# Altium schematic sheet EPM240T100C5N.SchDoc
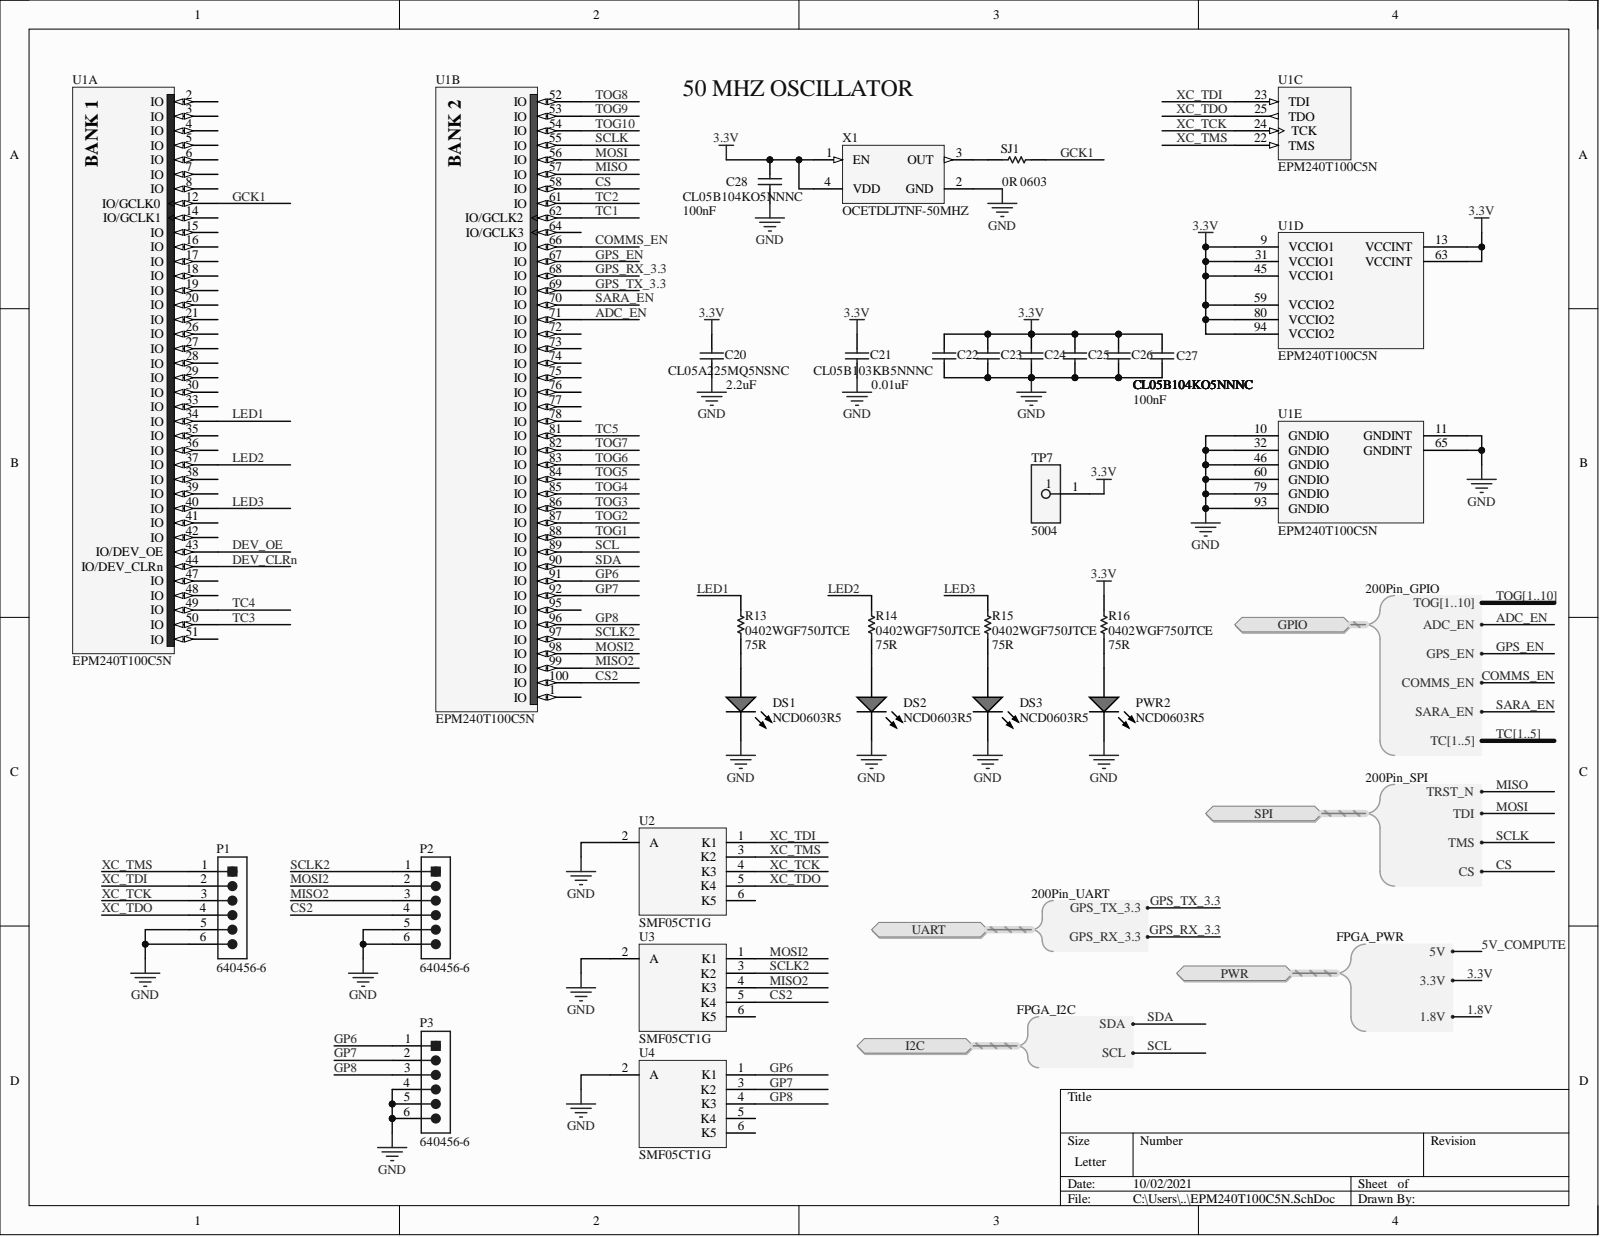
[diagram p0: EPM240T100C5N.SchDoc]

## Parts
C20  CL05A225MQ5NSNC  value 2.2uF  [CAPC0402(1005)60_M]
C21  CL05B103KB5NNNC  [CAPC1005X55X30NL05T10]
C22  CL05B104KO5NNNC  [CAPC1005X55X30ML05T10]
C23  CL05B104KO5NNNC  [CAPC1005X55X30ML05T10]
C24  CL05B104KO5NNNC  [CAPC1005X55X30ML05T10]
C25  CL05B104KO5NNNC  [CAPC1005X55X30ML05T10]
C26  CL05B104KO5NNNC  [CAPC1005X55X30ML05T10]
C27  CL05B104KO5NNNC  [CAPC1005X55X30ML05T10]
C28  CL05B104KO5NNNC  [CAPC1005X55X30ML05T10]
DS1  NCD0603R5  [AVAG-HSMX-C190_V]
DS2  NCD0603R5  [AVAG-HSMX-C190_V]
DS3  NCD0603R5  [AVAG-HSMX-C190_V]
P1  640456-6  [TECO-640456-6_V]
P2  640456-6  [TECO-640456-6_V]
P3  640456-6  [TECO-640456-6_V]
PWR2  NCD0603R5  [AVAG-HSMX-C190_V]
R13  0402WGF750JTCE  spec: 75R  [RESC1005X40X25ML05T10]
R14  0402WGF750JTCE  spec: 75R  [RESC1005X40X25ML05T10]
R15  0402WGF750JTCE  spec: 75R  [RESC1005X40X25ML05T10]
R16  0402WGF750JTCE  spec: 75R  [RESC1005X40X25ML05T10]
SJ1  0R 0603  [RESC1609X55X30ML10T20]
TP7  5004  [FP-5004-MFG]
U1  EPM240T100C5N  [TQFP100_L]  (parts A,B,C,D,E)
U2  SMF05CT1G  [ONSC-SC70-6-419B-02_W_V]
U3  SMF05CT1G  [ONSC-SC70-6-419B-02_W_V]
U4  SMF05CT1G  [ONSC-SC70-6-419B-02_W_V]
X1  OCETDLJTNF-50MHZ  [TXC-L500W700H130-4_V]

## Ports
GPIO, GPIO.ADC_EN, GPIO.COMMS_EN, GPIO.GPS_EN, GPIO.SARA_EN, GPIO.TC1, GPIO.TC2, GPIO.TC3, GPIO.TC4, GPIO.TC5, GPIO.TC[1..5], GPIO.TOG1, GPIO.TOG2, GPIO.TOG3, GPIO.TOG4, GPIO.TOG5, GPIO.TOG6, GPIO.TOG7, GPIO.TOG8, GPIO.TOG9, GPIO.TOG10, GPIO.TOG[1..10], I2C, I2C.SCL, I2C.SDA, PWR, PWR.1.8V, PWR.3.3V, PWR.5V, SPI, SPI.CS, SPI.TDI, SPI.TMS, SPI.TRST_N, UART, UART.GPS_RX_3.3, UART.GPS_TX_3.3

## Nets
GND: C20.1 C21.1 C22.1 C23.1 C24.1 C25.1 C26.1 C27.1 C28.1 DS1.2 DS2.2 DS3.2 P1.5 P1.6 P2.5 P2.6 P3.4 P3.5 P3.6 PWR2.2 U1.10(GNDIO) U1.11(GNDINT) U1.32(GNDIO) U1.46(GNDIO) U1.60(GNDIO) U1.65(GNDINT) U1.79(GNDIO) U1.93(GNDIO) U2.2(A) U3.2(A) U4.2(A) X1.2(GND)
3.3V: C20.2 C21.2 C22.2 C23.2 C24.2 C25.2 C26.2 C27.2 C28.2 R16.2 TP7.1 U1.9(VCCIO1) U1.13(VCCINT) U1.31(VCCIO1) U1.45(VCCIO1) U1.59(VCCIO2) U1.63(VCCINT) U1.80(VCCIO2) U1.94(VCCIO2) X1.1(EN) X1.4(VDD) ; port PWR
ADC_EN: U1.71(IO) ; port GPIO
COMMS_EN: U1.66(IO) ; port GPIO
CS: U1.58(IO) ; port SPI
CS2: P2.4 U1.100(IO) U3.5(K4)
DEV_CLRn: U1.44(IO/DEV_CLRn)
DEV_OE: U1.43(IO/DEV_OE)
GCK1: SJ1.2 U1.12(IO/GCLK0)
GP6: P3.1 U1.91(IO) U4.1(K1)
GP7: P3.2 U1.92(IO) U4.3(K2)
GP8: P3.3 U1.96(IO) U4.4(K3)
GPS_EN: U1.67(IO) ; port GPIO
GPS_RX_3.3: U1.68(IO) ; port UART
GPS_TX_3.3: U1.69(IO) ; port UART
LED1: R13.2 U1.34(IO)
LED2: R14.2 U1.37(IO)
LED3: R15.2 U1.40(IO)
MISO: U1.57(IO) ; port SPI
MISO2: P2.3 U1.99(IO) U3.4(K3)
MOSI: U1.56(IO) ; port SPI
MOSI2: P2.2 U1.98(IO) U3.1(K1)
SARA_EN: U1.70(IO) ; port GPIO
SCL: U1.89(IO) ; port I2C
SCLK: U1.55(IO) ; port SPI
SCLK2: P2.1 U1.97(IO) U3.3(K2)
SDA: U1.90(IO) ; port I2C
TC1: U1.62(IO/GCLK2) ; port GPIO  [also labelled TC]
TC2: U1.61(IO) ; port GPIO  [also labelled TC]
TC3: U1.50(IO) ; port GPIO  [also labelled TC]
TC4: U1.49(IO) ; port GPIO  [also labelled TC]
TC5: U1.81(IO) ; port GPIO  [also labelled TC]
TOG1: U1.88(IO) ; port GPIO  [also labelled TOG]
TOG2: U1.87(IO) ; port GPIO  [also labelled TOG]
TOG3: U1.86(IO) ; port GPIO  [also labelled TOG]
TOG4: U1.85(IO) ; port GPIO  [also labelled TOG]
TOG5: U1.84(IO) ; port GPIO  [also labelled TOG]
TOG6: U1.83(IO) ; port GPIO  [also labelled TOG]
TOG7: U1.82(IO) ; port GPIO  [also labelled TOG]
TOG8: U1.52(IO) ; port GPIO  [also labelled TOG]
TOG9: U1.53(IO) ; port GPIO  [also labelled TOG]
TOG10: U1.54(IO) ; port GPIO  [also labelled TOG]
XC_TCK: P1.3 U1.24(TCK) U2.4(K3)
XC_TDI: P1.2 U1.23(TDI) U2.1(K1)
XC_TDO: P1.4 U1.25(TDO) U2.5(K4)
XC_TMS: P1.1 U1.22(TMS) U2.3(K2)
NetDS1_1: DS1.1 R13.1
NetDS2_1: DS2.1 R14.1
NetDS3_1: DS3.1 R15.1
NetPWR2_1: PWR2.1 R16.1
NetSJ1_1: SJ1.1 X1.3(OUT)
NetU1_1: U1.1(IO)
NetU1_2: U1.2(IO)
NetU1_3: U1.3(IO)
NetU1_4: U1.4(IO)
NetU1_5: U1.5(IO)
NetU1_6: U1.6(IO)
NetU1_7: U1.7(IO)
NetU1_8: U1.8(IO)
NetU1_14: U1.14(IO/GCLK1)
NetU1_15: U1.15(IO)
NetU1_18: U1.18(IO)
NetU1_19: U1.19(IO)
NetU1_20: U1.20(IO)
NetU1_21: U1.21(IO)
NetU1_26: U1.26(IO)
NetU1_27: U1.27(IO)
NetU1_33: U1.33(IO)
NetU1_35: U1.35(IO)
NetU1_36: U1.36(IO)
NetU1_39: U1.39(IO)
NetU1_41: U1.41(IO)
NetU1_42: U1.42(IO)
NetU1_64: U1.64(IO/GCLK3)
NetU1_74: U1.74(IO)
NetU1_77: U1.77(IO)
NetU1_78: U1.78(IO)
NetU1_95: U1.95(IO)
NetU2_6: U2.6(K5)
NetU3_6: U3.6(K5)
NetU4_5: U4.5(K4)
NetU4_6: U4.6(K5)
1.8V: ; port PWR
5V_COMPUTE: ; port PWR

Not connected: U1 16(IO) 17(IO) 28(IO) 29(IO) 30(IO) 38(IO) 47(IO) 48(IO) 51(IO) 72(IO) 73(IO) 75(IO) 76(IO)

## Library data of the parts
C20 = CMP-1034-02125-1: 2.2uF 0402; Case-EIA: 0402; Case-Metric: 1005; Rated Voltage: 6.3 V; Tolerance: Â±10%; Component Kind: Standard; Library Name: Altium Content Vault; Max Thickness: 0.6 mm; Technology: SMT
C21 = CMP-2008-03072-1: 0.01uF 0402; Manufacturer: Kemet; Part Number: C0402C103K4RACTU; Component Kind: Standard; Library Name: Altium Content Vault
C22-C28 = CMP-2008-03080-1: 100nF 0402; Manufacturer: Kemet; Part Number: C0402C104J4RACTU; Component Kind: Standard; Library Name: Altium Content Vault
DS1-DS3 PWR2 = CMP-1744-00002-1: Chip LED, Green, 2.2 V, -40 to 85 degC, 2-Pin SMD, RoHS, Tape and Reel; Access Time: 3500 ns; Case\Package: SOIC; Clock Frequency: 100 KHz; Density: 32 kb; Frequency: 400 KHz; Interface: Serial; Max Supply Voltage: 5.5 V; Min Supply Voltage: 1.7 V; Nominal Supply Current: 2 mA; Component Kind: Standard; ComponentLink1Description: Manufacturer URL; ComponentLink1URL: http://www.avagotech.com/; ComponentLink2Description: Datasheet; ComponentLink2URL: http://docs.avagotech.com/docs/AV02-0551EN; ComponentLink3Description: Package Specification; ComponentLink3URL: http://www.fairchildsemi.com/dwg/MA/MA03D.pdf; DatasheetVersion: Mar-12; Height: 1.5 mm; Length: 5 mm; Library Name: Altium Content Vault; Max Clock Frequency: 100 KHz; Max Operating Temperature: 85 degC; Min Operating Temperature: -40 degC; Mount: Surface Mount; Package Quantity: 3000; PackageDescription: 2-Pin Surface Mount Device, Body 1.6 x 0.8 mm, Height 0.9 mm; PackageReference: HSMX-C190; Packaging: Tape and Reel; Pins: 8; Radiation Hardening: No; REACH SVHC: No SVHC; RoHSCompliant: true; Width: 4 mm
P1-P3 = CMP-2000-05661-1: Male Header, Pitch 2.54 mm, 1 x 6 Position, Height 10.03 mm, Tail Length 3.56 mm, -55 to 105 degC, Bulk; Manufacturer: TE Connectivity; Agency Approvals: CSA,UL; Angle: Straight; Brand/Series: MTA-100Series; Color, Housing: White; Connector Type: MTA-100; Contact Centerline Spacing: 0.100    in.; Contact Termination Area Plating: Tin; Current, Rating: 5    A; Material, Contact: CopperAlloy; Material, Housing: Polyester; Mount Angle: Vertical; Mounting Hole Size: 0.04    "; Number of Contacts: 6; Pin Spacing: 0.1    "; Primary Type: InterconnectSystem; Special Features: Pinstrip; Standards: RoHSCompliant,ELVCompliant,UL94V-0; Temperature, Operating: -55to+105    °C; Voltage, Rating: 250    V; Color: White; Component Kind: Standard; Contact Mating Area Plating: Tin; Contact Plating: Tin; Contact Shape: Square; Datasheet URL: https://datasheet.ciiva.com/25618/0900766b80eb7fdd-25618163.pdf; Datasheet Version: Rev. W, 05/2005; Flammability Rating: UL94V-0; Length, Overall: 0.6    "; Length, Pin: 0.14    "; Length, Terminal Post: 0.14    in.; Library Name: Altium Content Vault; Manufacturer URL: http://www.te.com/; Mounting Technology: ThroughHole; Mounting Type: PCMount; Number of Positions: 6; Number of Rows: 1; Package Description: Male Header, Pitch 2.54 mm, 1 x 6 Position, Height 10.03 mm, Tail Length 3.56 mm; Package Reference: 640456-6; Size, Post: 0.025    in.; Termination: Solder; Type: PCBPlug
R13-R16 = CMP-2002-07344-1: 75R 0402; Manufacturer: Yageo / Phycomp; Part Number: RC0402FR-07100RL; Component Kind: Standard; Library Name: Altium Content Vault
SJ1 = CMP-2000-03816-1: Manufacturer: Vishay Dale; Part Number: CRCW06030000Z0EA; Component Kind: Standard; Library Name: Altium Content Vault
TP7 = CMP-2000-05575-2: PC TEST POINT MINIATURE YELLOW; Manufacturer: Keystone; Is Female: yes; Number Of Contacts: 1; Automotive: No; Category: Conn; Component Kind: Standard; ComponentLink2Description: Datasheet; ComponentLink2URL: https://www.keyelco.com/product-pdf.cfm?p=1313; Contact Material: Silver; Device Class L1: Connectors; Device Class L2: unset; Device Class L3: unset; Digikey Description: PC TEST POINT MINIATURE YELLOW; Height: 4.72mm; Lead Free: Yes; Library Name: Altium Content Vault; Manufacturer Part Number: 5004; Mouser Description: TEST POINT PC MINI .040"D YELLOW; Octopart: https://octopart.com/5004-keystone-28591; Package: 5004; RoHS: TRUE
U1 = CMP-0144-00227-1: MAX II 3.3/2.5V CPLD, 80 IOs, 240 Logic Elements, 100-Pin Plastic TQFP, Commercial Temperature, Speed Grade 5, Pb-Free; Note1: Each set of VCCIO pins can be connected to 3.3V, 2.5V, 1.8V, or 1.5V.; Note2: All VCCINT pins must be connected to either 3.3V or 2.5V (but not a combination of both).; Code_JEDEC: MS-026-AED; Component Kind: Standard; DatasheetVersion: ver1.8, Jul-2006; Library Name: Altium Content Vault; PackageDescription: 100-Pin Plastic Thin Quad Flat Pack (TQFP100) - Wire Bond; PackageReference: TQFP100; PackageVersion: ver15.1, May-2008
U2-U4 = CMP-1061-00155-1: 5-Line Transient Voltage Suppressor Array, 6-Pin SC70, Pb-Free, Tape and Reel; Manufacturer: On Semiconductor; Direction: Unidirectional; IR Max (uA): 5; PPK Max (W): 100; Component Kind: Standard; ComponentLink1Description: Manufacturer URL; ComponentLink1URL: http://www.onsemi.com/; ComponentLink2Description: Datasheet; ComponentLink2URL: http://www.onsemi.com/pub_link/Collateral/SMF05C-D.PDF; ComponentLink3Description: Package Specification; ComponentLink3URL: http://www.onsemi.com/pub_link/Collateral/SMF05C-D.PDF; DatasheetVersion: Rev. 4; Library Name: Altium Content Vault; Mounting Technology: Surface Mount; PackageDescription: 6-Pin Small Outline Transistor, Body 2 x 1.25 mm, Pitch 0.65 mm; PackageReference: SC70-6-419B-02_W; PackageVersion: Rev. W; Packing: Tape and Reel; PartNumber: SMF05CT1G; RoHS: Pb-Free
X1 = CMP-0269-00001-1: OSC, 50.000 MHZ, ± 25 ppm, 3.3 V, SMT  OCETDLJTNF-50MHZ; PartNumber: 7W-50.000MBA-T; Body Shape: Rectangular, 4 SMD; Frequency Stability: Â± 25 ppm(-10 to +70 degC); Rise/Fall Time: 7 ns; Component Kind: Standard; ComponentLink1Description: Manufacturer URL; ComponentLink1URL: http://www.txccrystal.com/; ComponentLink2Description: Datasheet; ComponentLink2URL: http://www.txccrystal.com/images/pdf/7w-tight.pdf; Library Name: Altium Content Vault; Mounting Technology: Surface Mount; Operating Temperature Range: -40 to 85 degC; PackageDescription: 4-Pin SMT LED, 7 x 5 mm Body; PackageReference: L500W700H130-4; Storage Temperature: -50 to 125 degC
